# Altium schematic sheet [06] - WIRELESS.SchDoc("[06] - WIRELESS")
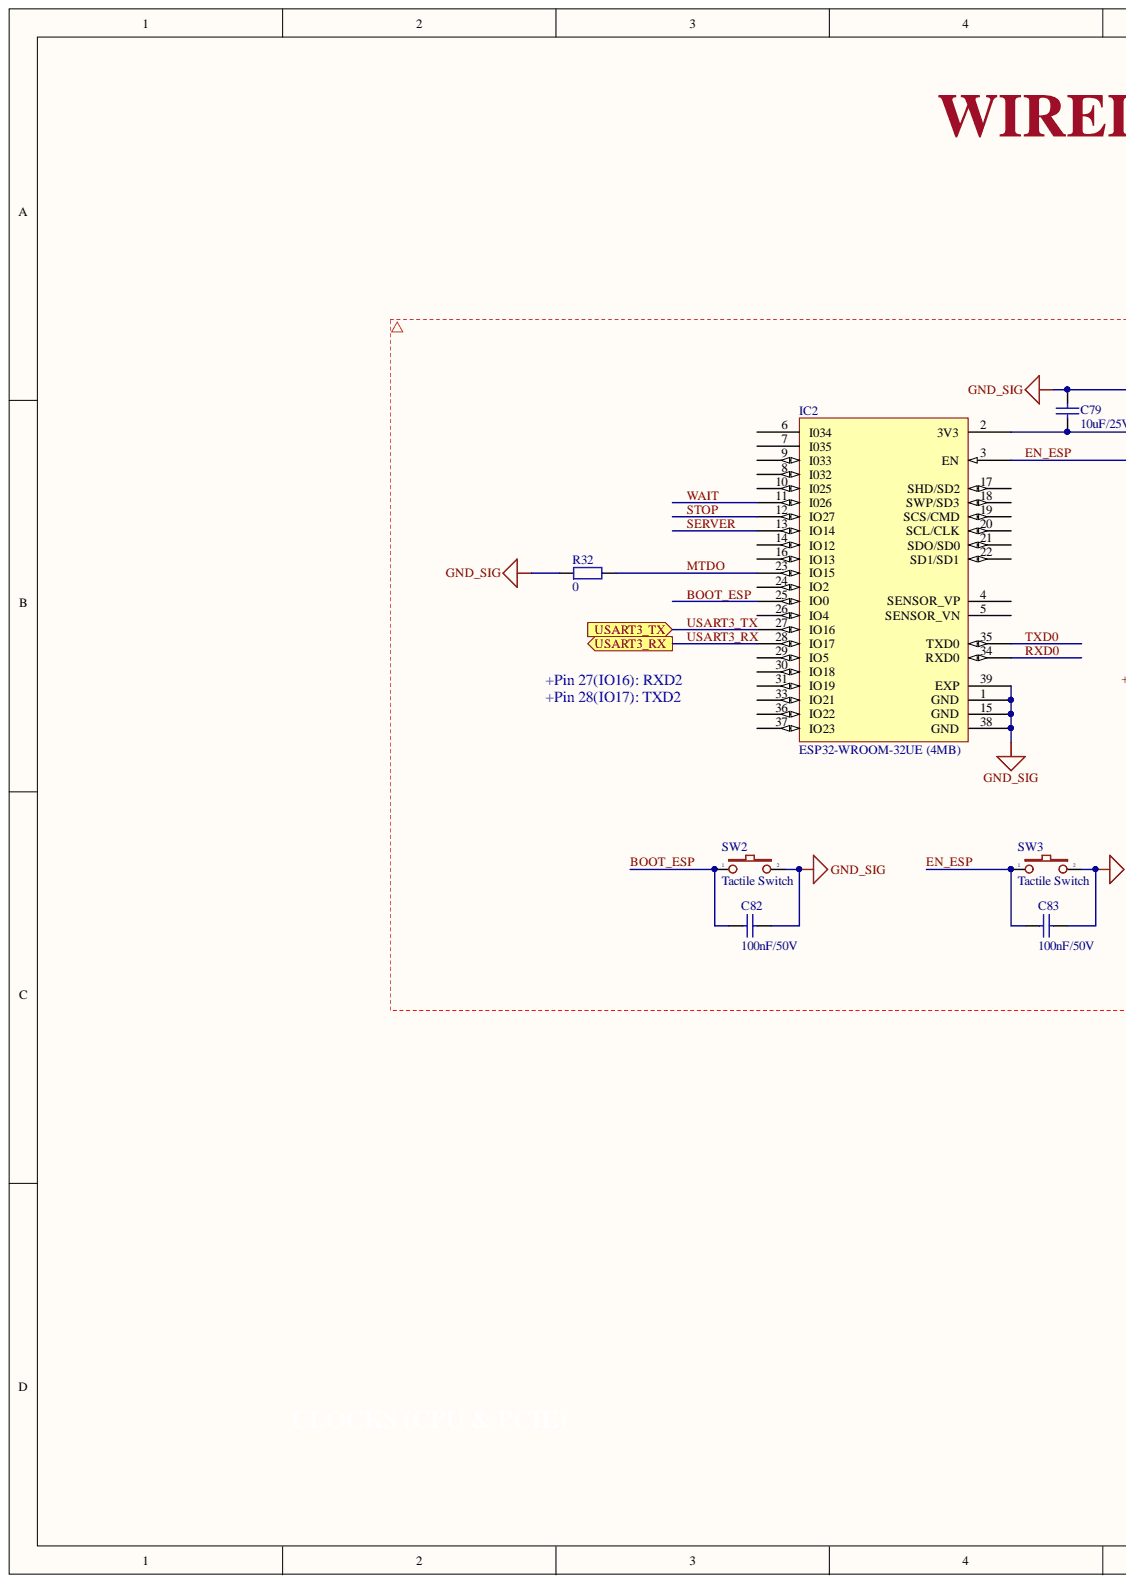
[diagram p0: [06] - WIRELESS.SchDoc, left]
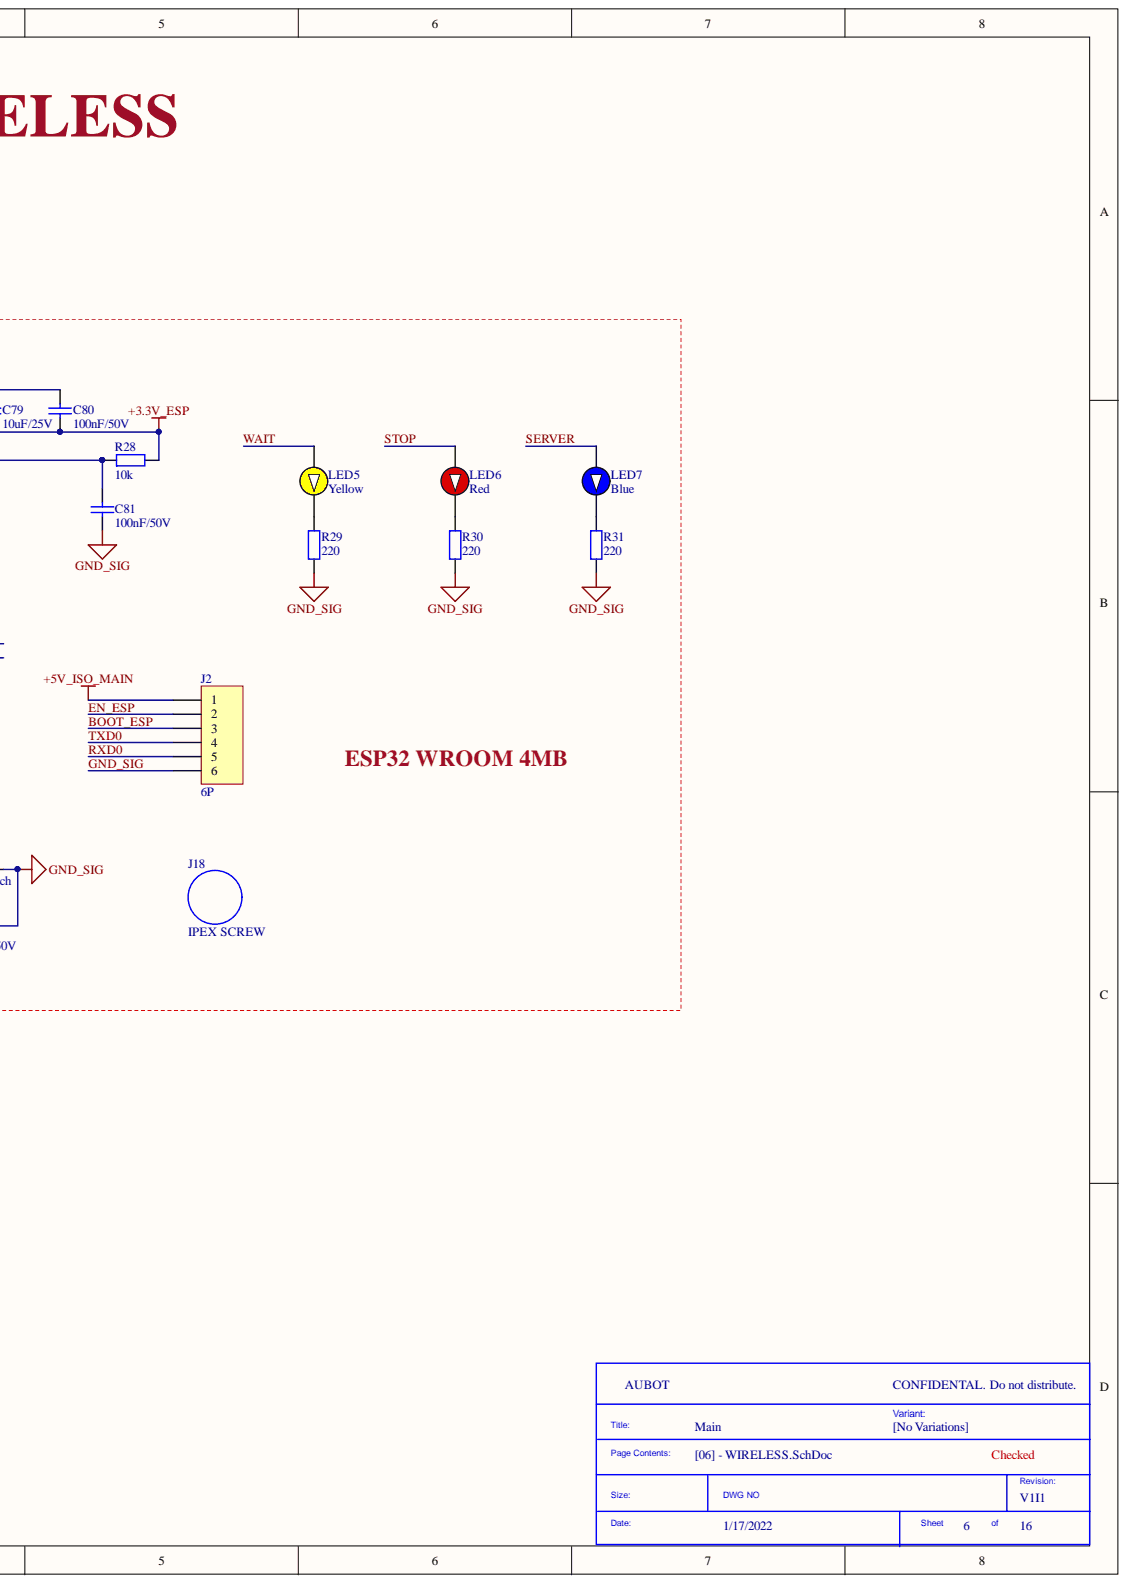
[diagram p1: [06] - WIRELESS.SchDoc, right]

## Parts
C79  10uF/25V  [CAP 0805]
C80  100nF/50V  [CAP 0805]
C81  100nF/50V  [CAP 0805]
C82  100nF/50V  [CAP 0805]
C83  100nF/50V  [CAP 0805]
IC2  ESP32-WROOM-32UE (4MB)  [ESP32]
J2  6P  [HEADER 2.54 6P VERTICAL]
J18  IPEX SCREW  [IPEX SCREW]
LED5  Yellow  [LED 0805]
LED6  Red  [LED 0805]
LED7  Blue  [LED 0805]
R28  10k  [RES 0805]
R29  220  [RES 0805]
R30  220  [RES 0805]
R31  220  [RES 0805]
R32  0  [RES 0805]
SW2  Tactile Switch  [Tactile Switch 6x3.5]
SW3  Tactile Switch  [Tactile Switch 6x3.5]

## Ports
USART3_RX, USART3_TX

## Nets
+3.3V_ESP: C79.2 C80.2 IC2.2(3V3) R28.2
+5V_ISO_MAIN: J2.1
BOOT_ESP: C82.2 IC2.25(IO0) J2.3 SW2.1
EN_ESP: C81.2 C83.2 IC2.3(EN) J2.2 R28.1 SW3.1
GND_SIG: C79.1 C80.1 C81.1 C82.1 C83.1 IC2.1(GND) IC2.15(GND) IC2.38(GND) IC2.39(EXP) J2.6 R29.2 R30.2 R31.2 R32.1 SW2.2 SW3.2
MTDO: IC2.23(IO15) R32.2
RXD0: IC2.34(RXD0) J2.5
SERVER: IC2.13(IO14) LED7.1
STOP: IC2.12(IO27) LED6.1
TXD0: IC2.35(TXD0) J2.4
USART3_RX: IC2.28(IO17) ; port USART3_RX
USART3_TX: IC2.27(IO16) ; port USART3_TX
WAIT: IC2.11(I026) LED5.1
NetLED5_2: LED5.2 R29.1
NetLED6_2: LED6.2 R30.1
NetLED7_2: LED7.2 R31.1

Not connected: IC2 4(SENSOR_VP) 5(SENSOR_VN) 6(I034) 7(I035) 8(I032) 9(I033) 10(I025) 14(IO12) 16(IO13) 17(SHD/SD2) 18(SWP/SD3) 19(SCS/CMD) 20(SCL/CLK) 21(SDO/SD0) 22(SD1/SD1) 24(IO2) 26(IO4) 29(IO5) 30(IO18) 31(IO19) 33(IO21) 36(IO22) 37(IO23)

## Library data of the parts
C79 = Cap 0805 10uF/25V: 0805 10 uF 25 V ±10 % Tolerance X5R SMT Multilayer Ceramic Capacitor; Manufacturer Part Number: CC0805KKX5R8BB106; Manufacturer: Yageo; Voltage Rating (DC): 25V; Tolerance: 10%; Capacitance: 10uF; Width: 0.049inch; Weight: 0.000194oz; Voltage Rating: 25V; Thickness: 0.057inch; Termination: SMD/SMT; Supplier Part Number 1: 311-1869-1-ND; Supplier 1: Digi-Key; Stock 1: 149609; Series: CC; RoHS Compliant: Yes; RoHS: Yes; Resistor Type: Ceramic; Pricing 1: 1 = 0.55000, 10 = 0.38500, 50 = 0.26960, 100 = 0.23120, 500 = 0.17336, 1000 = 0.14640 (USD); Packaging: Tape and Reel; Mount: Surface Mount; Min Operating Temperature: -55°C; Max Operating Temperature: 85°C; Length: 0.079inch; Lead Free: Lead Free; Halogen Free: Halogen Free; Dielectric: X5R; Depth: 1.25mm; ComponentLink3URL: http://www.yageo.com/documents/recent/UPY-GPHC_X5R_4V-to-50V_25.pdf?src-supplier=Digi-Key; ComponentLink3Description: http://www.yageo.com/documents/recent/UPY-GPHC_X5R_4V-to-50V_25.pdf?src-supplier=Digi-Key; ComponentLink2URL: http://www.mouser.com/ds/2/447/UPY-GPHC_X5R_4V-to-50V_25-1131599.pdf?src-supplier=Mouser; ComponentLink2Description: http://www.mouser.com/ds/2/447/UPY-GPHC_X5R_4V-to-50V_25-1131599.pdf?src-supplier=Mouser; ComponentLink1URL: http://components.arrow.com/part/dataview/0323_04166572?src-supplier=Arrow+Europe; ComponentLink1Description: http://components.arrow.com/part/dataview/0323_04166572?src-supplier=Arrow+Europe; Component Kind: Standard; Case Code (Metric): 2012; Case Code (Imperial): 0805; Case/Package: 0805; Library Name: Hieu_Lib.SchLib
C80-C83 = Cap 0805 100nF/50V: Ceramic capacitor SMD-0805 100nF /50V X7R# K +-10%, Samsung, RoHS; Manufacturer Part Number: CL21B104KBCNNNC; Voltage Rating (DC): 50V; Tolerance: 10%; Dielectric: X7R; Width: 0.049inch; Voltage Rating: 50V; Voltage: 50V; Thickness: 0.037inch; Supplier Part Number 1: C1711; Supplier 1: LCSC; Stock 1: 447650; Series: CL; RoHS Compliant: Yes; RoHS: Yes; Radiation Hardening: No; Pricing 1: 50 = 0.04090, 500 = 0.03130, 1500 = 0.02890, 5000 = 0.02580, 25000 = 0.02430, 50000 = 0.02410, 50 = 0.03950, 500 = 0.03020, 1500 = 0.02790, 5000 = 0.02490, 25000 = 0.02350, 50000 = 0.02330, 50 = 0.20680, 500 = 0.15820, 1500 = 0.14600, 5000 = 0.13020, 25000 = 0.12290, 50000 = 0.12170, 50 = 0.19640, 500 = 0.15020, 1500 = 0.13860, 5000 = 0.12360, 25000 = 0.11670, 50000 = 0.11550, 50 = 0.02640, 500 = 0.02020, 1500 = 0.01860, 5000 = 0.01660, 25000 = 0.01570, 50000 = 0.01550, 50 = 0.02300, 500 = 0.01760, 1500 = 0.01620, 5000 = 0.01440, 25000 = 0.01360, 50000 = 0.01350, 50 = 0.24740, 500 = 0.18920, 1500 = 0.17470, 5000 = 0.15570, 25000 = 0.14700, 50000 = 0.14560, 50 = 3.45220, 500 = 2.64000, 1500 = 2.43700, 5000 = 2.17300, 25000 = 2.05110, 50000 = 2.03080, 50 = 0.13070, 500 = 0.09990, 1500 = 0.09220, 5000 = 0.08230, 25000 = 0.07760, 50000 = 0.07690, 50 = 2.39050, 500 = 1.82810, 1500 = 1.68750, 5000 = 1.50470, 25000 = 1.42030, 50000 = 1.40620, 50 = 0.26740, 500 = 0.20450, 1500 = 0.18870, 5000 = 0.16830, 25000 = 0.15890, 50000 = 0.15730, 50 = 0.04230, 500 = 0.03230, 1500 = 0.02990, 5000 = 0.02660, 25000 = 0.02510, 50000 = 0.02490, 50 = 0.03188, 500 = 0.02438, 1500 = 0.02251, 5000 = 0.02007, 25000 = 0.01894, 50000 = 0.01875 (AUD); Packaging: Tape and Reel; Mount: Surface Mount; Min Operating Temperature: -55°C; Max Operating Temperature: 125°C; Material: Ceramic; Manufacturer: Samsung; Length: 2mm; Lead Free: Lead Free; Height: 0.85mm; Depth: 1.25mm; Contact Plating: Tin; Construction: Flat; ComponentLink2URL: https://datasheet.ciiva.com/29199/454152-da-01-en-vs-kondensator-0805-29199814.pdf?src-supplier=Conrad; ComponentLink2Description: https://datasheet.ciiva.com/29199/454152-da-01-en-vs-kondensator-0805-29199814.pdf?src-supplier=Conrad; ComponentLink1URL: https://datasheet.ciiva.com/25710/cl21b104kbcnnnc-25710182.pdf?src-supplier=Digi-Key; ComponentLink1Description: https://datasheet.ciiva.com/25710/cl21b104kbcnnnc-25710182.pdf?src-supplier=Digi-Key; Component Kind: Standard; Case Code (Metric): 2012; Case Code (Imperial): 0805; Case/Package: 0805; Capacitance: 100nF; Library Name: Hieu_Lib.IntLib
IC2 = ESP32-WROOM-32UE (4MB): SDM38,18x19.2x3.2 WiFi Modules ROHS; Manufacturer: Espressif Systems; Supplier Part Number 1: C701344; Supplier 1: LCSC; Pricing: 1 = 3.3271; Manufacturer Part Number: ESP32-WROOM-32UE(4MB); Component Kind: Standard; Library Name: Hieu_Lib.SchLib
J2 = Header 2.54 6P: 0.098"(2.50mm) 6 MalePin Header XH Through Hole Wire To Board / Wire To Wire Connector ROHS; Manufacturer Part Number: XH-6A; Manufacturer: BOOMELE(Boom Precision Elec); Supplier Part Number 1: C5663; Supplier 1: LCSC; Component Kind: Standard; Library Name: Hieu_Lib.IntLib
LED5 = Led 0805 Yellow: Yellow SMD,2x1.25x0.8mm Light Emitting Diodes (LED) ROHS; Manufacturer Part Number: E6C0805UYAC1UDA; Manufacturer: EKINGLUX; Supplier Part Number 1: C375452; Supplier 1: LCSC; Component Kind: Standard; Library Name: Hieu_Lib.IntLib
LED6 = Led 0805 Red: Red 0805 Light Emitting Diodes (LED) ROHS; Manufacturer Part Number: 17-21SURC/S530-A3/TR8; Manufacturer: Everlight Elec; Supplier Part Number 1: C72037; Supplier 1: LCSC; Component Kind: Standard; Library Name: Hieu_Lib.IntLib
LED7 = Led 0805 Blue: Blue 0805 Light Emitting Diodes (LED) ROHS; Manufacturer Part Number: ORH-B35A; Manufacturer: Orient; Supplier Part Number 1: C205441; Supplier 1: LCSC; Component Kind: Standard; Library Name: Hieu_Lib.IntLib
R28 = Res 0805 10k Ohm 1%: Res SMD 0805 10K 1% 0.125W T.C.100PPM; Manufacturer Part Number: RC0805FR-0710KL; Manufacturer: Yageo; Voltage Rating: 150V; Temperature Coefficient: 100ppm/°C; Resistance: 10kR; Power Rating: 125mW; Width: 0.049inch; Tolerance: 1%; Termination: SMD/SMT; Technology: THICK FILM; Supplier Part Number 1: 311-10.0KCRCT-ND; Supplier 1: Digi-Key; Stock 1: 4587552; Size Code: 0805; Series: RC; RoHS Compliant: Yes; RoHS: Yes; Resistor Type: Thick Film; Resistance Tolerance: 1%; REACH SVHC: Unknown; Pricing 1: 1 = 0.10000, 10 = 0.04200, 100 = 0.01690, 1000 = 0.00759, 2500 = 0.00659 (USD); Packaging: Tape and Reel; Package Quantity: 1; Number of Terminations: 2; Min Operating Temperature: -55°C; Military Standard: Not; Max Power Dissipation: 125mW; Max Operating Temperature: 155°C; Length: 2mm; Lead Free: Lead Free; Height: 0.024inch; Features: Moisture Resistant; Depth: 1.25mm; Construction: Rectangular; Component Kind: Standard; Case Code (Metric): 2012; Case Code (Imperial): 0805; Case/Package: 0805; Library Name: Hieu_Lib.IntLib
R29-R31 = Res 0805 220 Ohm 1%: Res SMD 0805 220R 1% 0.125W T.C.100PPM; Manufacturer Part Number: RC0805FR-07220RL; Manufacturer: Yageo; Voltage Rating: 150V; Temperature Coefficient: 100ppm/°C; Power Rating: 125mW; Width: 0.049inch; Tolerance: 1%; Termination: SMD/SMT; Technology: THICK FILM; Supplier Part Number 1: 311-220CRCT-ND; Supplier 1: Digi-Key; Stock 1: 316498; Size Code: 0805; Series: RC; RoHS Compliant: Yes; RoHS: Yes; Resistor Type: Thick Film; Resistance Tolerance: 1%; Resistance: 220R; REACH SVHC: Unknown; Pricing 1: 1 = 0.10000, 10 = 0.04200, 100 = 0.01690, 1000 = 0.00759, 2500 = 0.00659 (USD); Packaging: Tape and Reel; Package Quantity: 5000; Number of Terminations: 2; Min Operating Temperature: -55°C; Military Standard: Not; Max Power Dissipation: 125mW; Max Operating Temperature: 155°C; Length: 2mm; Lead Free: Lead Free; Height: 0.5mm; Features: Moisture Resistant; Depth: 1.25mm; Construction: Rectangular; ComponentLink6URL: https://datasheet.ciiva.com/7941/444164-7941741.pdf?src-supplier=Element14; ComponentLink6Description: https://datasheet.ciiva.com/7941/444164-7941741.pdf?src-supplier=Element14; ComponentLink5URL: https://datasheet.ciiva.com/7941/444164-7941740.pdf?src-supplier=Element14; ComponentLink5Description: https://datasheet.ciiva.com/7941/444164-7941740.pdf?src-supplier=Element14; ComponentLink4URL: https://datasheet.ciiva.com/2457/pyu-r-int-thick-7-2457385.pdf?src-supplier=Digi-Key; ComponentLink4Description: https://datasheet.ciiva.com/2457/pyu-r-int-thick-7-2457385.pdf?src-supplier=Digi-Key; ComponentLink3URL: https://datasheet.ciiva.com/2457/pyu-r-int-thick-7-2457384.pdf?src-supplier=Digi-Key; ComponentLink3Description: https://datasheet.ciiva.com/2457/pyu-r-int-thick-7-2457384.pdf?src-supplier=Digi-Key; ComponentLink2URL: https://datasheet.ciiva.com/2457/pyu-r-int-thick-7-2457383.pdf?src-supplier=Digi-Key; ComponentLink2Description: https://datasheet.ciiva.com/2457/pyu-r-int-thick-7-2457383.pdf?src-supplier=Digi-Key; ComponentLink1URL: https://datasheet.ciiva.com/11808/0900766b8106beab-11808180.pdf?src-supplier=RSComponents; ComponentLink1Description: https://datasheet.ciiva.com/11808/0900766b8106beab-11808180.pdf?src-supplier=RSComponents; Component Kind: Standard; Case Code (Metric): 2012; Case Code (Imperial): 0805; Case/Package: 0805; Library Name: Hieu_Lib.IntLib
R32 = Res 0805 0 Ohm 1%: RC Series 0805 0.125 W 0 Ohm Jumper SMT Thick Film Chip Resistor; Manufacturer Part Number: RC0805FR-070RL; Manufacturer: Yageo; Tolerance: 1%; Resistance: 0mR; Power Rating: 125mW; Supplier Part Number 1: 311-0.0CRCT-ND; Supplier 1: Digi-Key; Stock 1: 579408; Size Code: 0805; Series: RC; RoHS Compliant: Yes; RoHS: Yes; Resistor Type: Thick Film; Radiation Hardening: No; Pricing 1: 1 = 0.10000, 10 = 0.03200, 25 = 0.02360, 50 = 0.01780, 100 = 0.01320, 250 = 0.01004, 500 = 0.00802, 1000 = 0.00591 (USD); Packaging: Tape and Reel; Number of Terminations: 2; Min Operating Temperature: -55°C; Military Standard: Not; Max Power Dissipation: 125mW; Max Operating Temperature: 155°C; Length: 2mm; Lead Free: Lead Free; Features: Moisture Resistant; Depth: 1.25mm; Contact Plating: Tin,Matte; Construction: Rectangular; ComponentLink2URL: https://datasheet.ciiva.com/15189/getdatasheetpartid-348154-15189885.pdf?src-supplier=Verical; ComponentLink2Description: https://datasheet.ciiva.com/15189/getdatasheetpartid-348154-15189885.pdf?src-supplier=Verical; ComponentLink1URL: https://datasheet.ciiva.com/17042/rc0805-17042698.pdf?src-supplier=Future+Electronics; ComponentLink1Description: https://datasheet.ciiva.com/17042/rc0805-17042698.pdf?src-supplier=Future+Electronics; Component Kind: Standard; Case Code (Metric): 2012; Case Code (Imperial): 0805; Case/Package: 0805; Library Name: Hieu_Lib.IntLib
SW2 SW3 = Tactile Switch: PTS636 Series SPST-NO Off-Mom 180 gf Top Actuated F Lead SMT Tactile Switch; Manufacturer Part Number: PTS636SM25FSMTRLFS; Manufacturer: ITT C&K; Supplier Part Number 1: CKN12329-1-ND; Supplier 1: Digi-Key; Stock 1: 0; RoHS: No; Component Kind: Standard; Library Name: Hieu_Lib.IntLib
J18 = IPEX SCREW: Description: IPEX SCREW; Component Kind: Standard; Library Name: Hieu_Lib.IntLib
